# Altium schematic sheet senior_project_schem_REVB_2_26_2019_updated_without_sizes.SchDoc
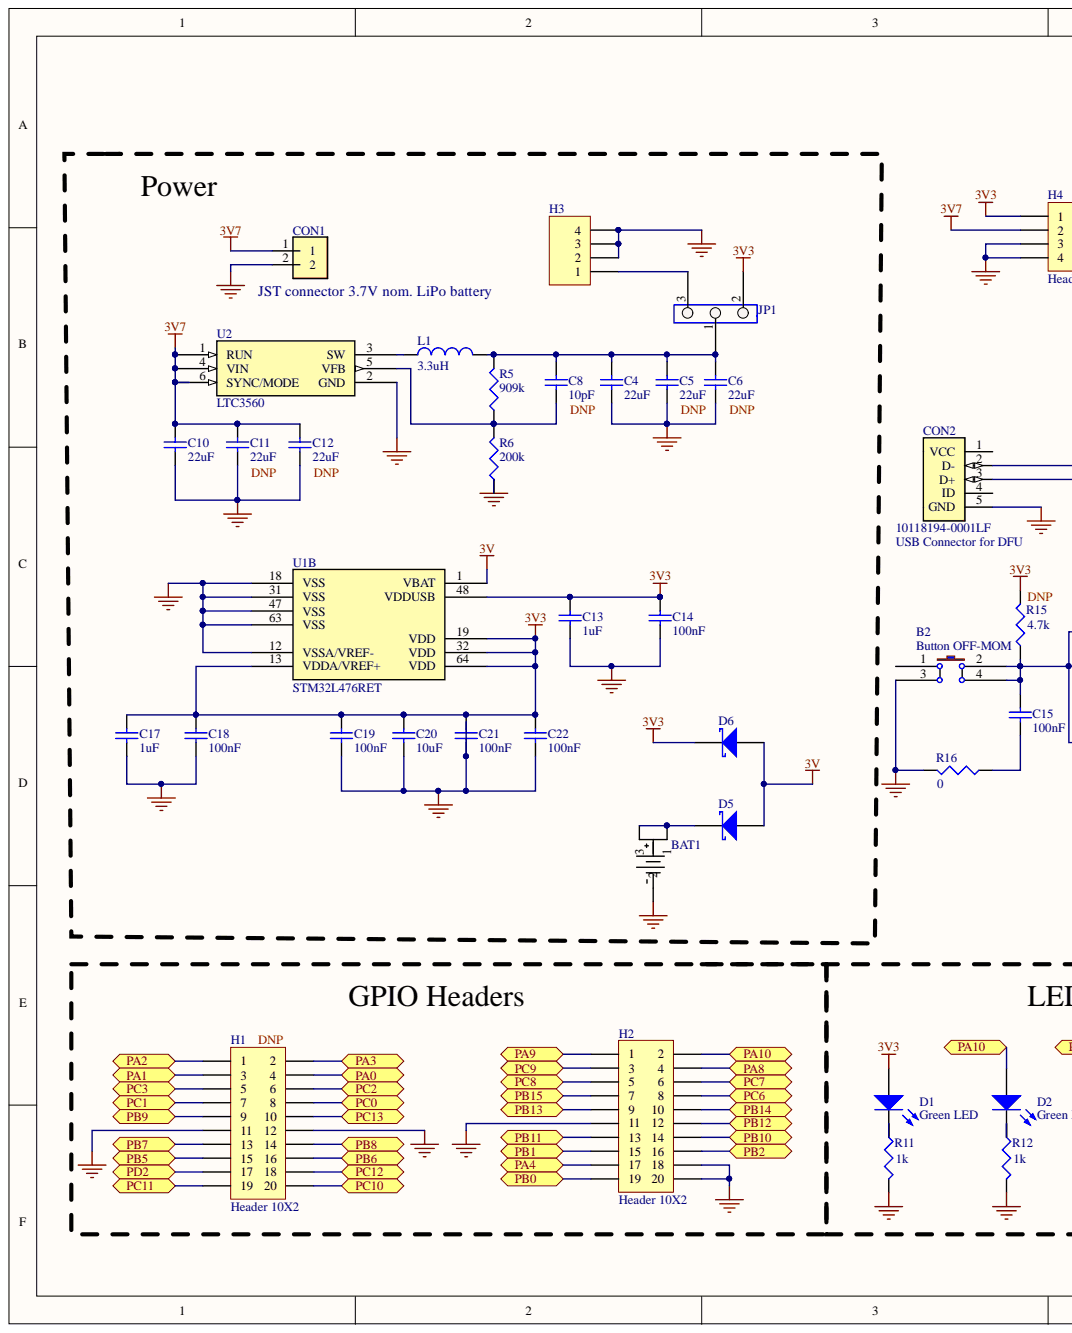
[diagram p0: senior_project_schem_REVB_2_26_2019_updated_without_sizes.SchDoc, left]
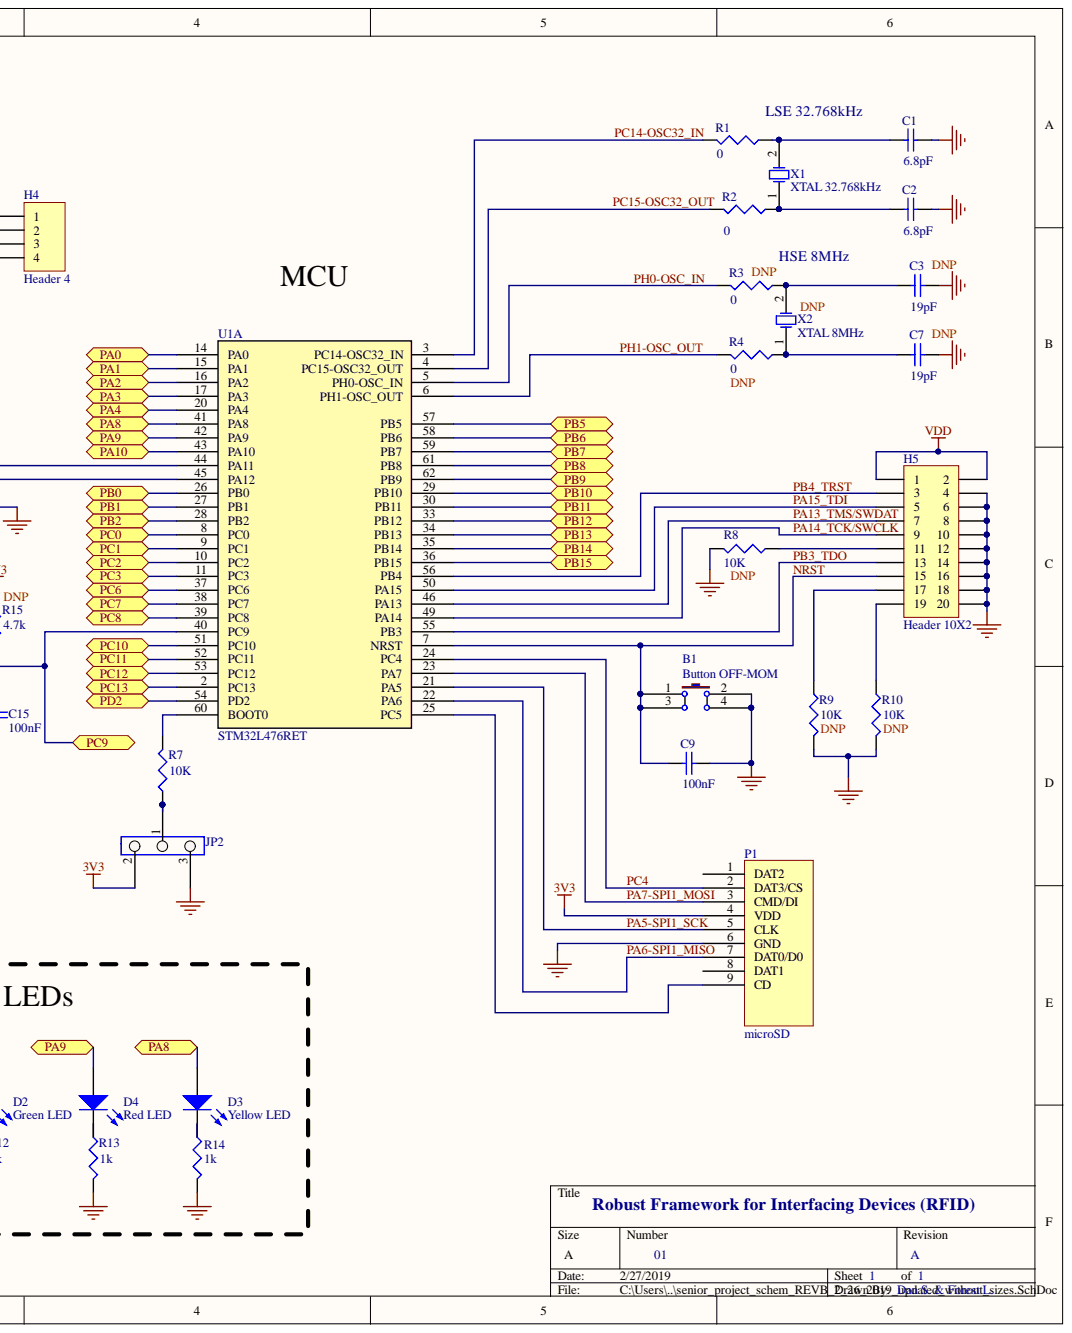
[diagram p1: senior_project_schem_REVB_2_26_2019_updated_without_sizes.SchDoc, right]

## Parts
B1  Button OFF-MOM  [9.5mmx5.6mm_SMD_Button]
B2  Button OFF-MOM  [9.5mmx5.6mm_SMD_Button]
BAT1  (no value)  [Keystone 3050 Batt Holder]
C1  value 6.8pF  [CAPC1005X55N]  (hidden comment: Cap 0402)
C2  value 6.8pF  [CAPC1005X55N]  (hidden comment: Cap 0402)
C3  value 19pF  [CAPC1005X55N]  (hidden comment: Cap 0402)
C4  22uF  [C_0805]
C5  22uF  [C_0805]
C6  22uF  [C_0805]
C7  value 19pF  [CAPC1005X55N]  (hidden comment: Cap 0402)
C8  10pF  [CAP_0603]
C9  value 100nF  [CAP_0603]  (hidden comment: Cap 0603)
C10  22uF  [C_0805]
C11  22uF  [C_0805]
C12  22uF  [C_0805]
C13  1uF  [CAP_0603]
C14  100nF  [CAP_0603]
C15  value 100nF  [CAP_0603]  (hidden comment: Cap 0603)
C17  1uF  [CAP_0603]
C18  100nF  [CAP_0603]
C19  100nF  [CAP_0603]
C20  10uF  [CAP_0603]
C21  100nF  [CAP_0603]
C22  100nF  [CAP_0603]
CON1  S2B-PH-SM4-TB(LF)(SN)  [JST_S2B-PH-SM4-TB(LF)(SN)]  (value not drawn)
CON2  10118194-0001LF  [FRAMATOME_10118194-0001LF]
D1  Green LED  [LED-0]
D2  Green LED  [LED-0]
D3  Yellow LED  [LED-0]
D4  Red LED  [LED-0]
D5  (no value)  [SMB]
D6  (no value)  [SMB]
H1  Header 10X2  [HDR2X10]
H2  Header 10X2  [HDR2X10]
H3  (no value)  [HDR1X4]
H4  Header 4  [HDR1X4]
H5  Header 10X2  [HDR2X10]
JP1  (no value)  [SIP3_HDR1X3]
JP2  (no value)  [SIP3_HDR1X3]
L1  3.3uH  [MAMK2520T3R3M]
P1  microSD  [WM12834CT-ND]
R1  value 0  [R_0603]  (hidden comment: Res 0603)
R2  value 0  [R_0603]  (hidden comment: Res 0603)
R3  value 0  [R_0603]  (hidden comment: Res 0603)
R4  value 0  [R_0603]  (hidden comment: Res 0603)
R5  909k  [R_0805]
R6  200k  [R_0805]
R7  value 10K  [R_0805]  (hidden comment: Res 0805)
R8  value 10K  [R_0805]  (hidden comment: Res 0805)
R9  value 10K  [R_0805]  (hidden comment: Res 0805)
R10  value 10K  [R_0805]  (hidden comment: Res 0805)
R11  value 1k  [R_0805]  (hidden comment: Res 0805)
R12  value 1k  [R_0805]  (hidden comment: Res 0805)
R13  value 1k  [R_0805]  (hidden comment: Res 0805)
R14  value 1k  [R_0805]  (hidden comment: Res 0805)
R15  value 4.7k  [R_0805]  (hidden comment: Res 0805)
R16  value 0  [R_0805]  (hidden comment: Res 0805)
U1  STM32L476RET  [LQFP64-10x10mm]  (parts A,B)
U2  LTC3560  [TSOT-23_S6]
X1  XTAL 32.768kHz  [ECS-.327-CDX-1128]
X2  XTAL 8MHz  [ECS-.327-CDX-1128]

## Ports
PA0, PA1, PA2, PA3, PA4, PA8, PA9, PA10, PB0, PB1, PB2, PB5, PB6, PB7, PB8, PB9, PB10, PB11, PB12, PB13, PB14, PB15, PC0, PC1, PC2, PC3, PC6, PC7, PC8, PC9, PC10, PC11, PC12, PC13, PD2

## Nets
3V: D5.1 D6.1 U1.1(VBAT)
3V3: C13.2 C14.2 C17.2 C18.2 C19.2 C20.2 C21.2 C22.2 D1.1 D6.2 H4.1 JP1.2 JP2.2 P1.4(VDD) R15.2 U1.13(VDDA/VREF+) U1.19(VDD) U1.32(VDD) U1.48(VDDUSB) U1.64(VDD)
3V7: C10.2 C11.2 C12.2 CON1.1 H4.2 U2.1(RUN) U2.4(VIN) U2.6(SYNC/MODE)
GND: B1.2 B1.4 B2.3 C1.1 C2.1 C3.2 C4.1 C5.1 C6.1 C7.2 C9.1 C10.1 C11.1 C12.1 C13.1 C14.1 C17.1 C18.1 C19.1 C20.1 C21.1 C22.1 CON1.2 CON2.5(GND) H1.11 H1.12 H2.11 H2.18 H2.20 H3.2 H3.3 H3.4 H4.3 H4.4 H5.4 H5.6 H5.8 H5.10 H5.12 H5.14 H5.16 H5.18 H5.20 JP2.3 P1.6(GND) R6.1 R8.2 R9.2 R10.2 R11.2 R12.2 R13.2 R14.2 R16.2 U1.12(VSSA/VREF-) U1.18(VSS) U1.31(VSS) U1.47(VSS) U1.63(VSS) U2.2(GND)
VDD: H5.1 H5.2
: BAT1.2
NRST: B1.1 B1.3 C9.2 H5.15 U1.7(NRST)
PA0: H1.4 U1.14(PA0) ; port PA0
PA1: H1.3 U1.15(PA1) ; port PA1
PA2: H1.1 U1.16(PA2) ; port PA2
PA3: H1.2 U1.17(PA3) ; port PA3
PA4: H2.17 U1.20(PA4) ; port PA4
PA5-SPI1_SCK: P1.5(CLK) U1.21(PA5)
PA6-SPI1_MISO: P1.7(DAT0/D0) U1.22(PA6)
PA7-SPI1_MOSI: P1.3(CMD/DI) U1.23(PA7)
PA8: D3.1 H2.4 U1.41(PA8) ; port PA8
PA9: D4.1 H2.1 U1.42(PA9) ; port PA9
PA10: D2.1 H2.2 U1.43(PA10) ; port PA10
PA13_TMS/SWDAT: H5.7 U1.46(PA13)
PA14_TCK/SWCLK: H5.9 U1.49(PA14)
PA15_TDI: H5.5 U1.50(PA15)
PB0: H2.19 U1.26(PB0) ; port PB0
PB1: H2.15 U1.27(PB1) ; port PB1
PB2: H2.16 U1.28(PB2) ; port PB2
PB3_TDO: H5.13 U1.55(PB3)
PB4_TRST: H5.3 U1.56(PB4)
PB5: H1.15 U1.57(PB5) ; port PB5
PB6: H1.16 U1.58(PB6) ; port PB6
PB7: H1.13 U1.59(PB7) ; port PB7
PB8: H1.14 U1.61(PB8) ; port PB8
PB9: H1.9 U1.62(PB9) ; port PB9
PB10: H2.14 U1.29(PB10) ; port PB10
PB11: H2.13 U1.30(PB11) ; port PB11
PB12: H2.12 U1.33(PB12) ; port PB12
PB13: H2.9 U1.34(PB13) ; port PB13
PB14: H2.10 U1.35(PB14) ; port PB14
PB15: H2.7 U1.36(PB15) ; port PB15
PC0: H1.8 U1.8(PC0) ; port PC0
PC1: H1.7 U1.9(PC1) ; port PC1
PC2: H1.6 U1.10(PC2) ; port PC2
PC3: H1.5 U1.11(PC3) ; port PC3
PC4: P1.2(DAT3/CS) U1.24(PC4)
PC6: H2.8 U1.37(PC6) ; port PC6
PC7: H2.6 U1.38(PC7) ; port PC7
PC8: H2.5 U1.39(PC8) ; port PC8
PC9: B2.2 B2.4 C15.1 H2.3 R15.1 U1.40(PC9) ; port PC9
PC10: H1.20 U1.51(PC10) ; port PC10
PC11: H1.19 U1.52(PC11) ; port PC11
PC12: H1.18 U1.53(PC12) ; port PC12
PC13: H1.10 U1.2(PC13) ; port PC13
PC14-OSC32_IN: R1.2 U1.3(PC14-OSC32_IN)
PC15-OSC32_OUT: R2.2 U1.4(PC15-OSC32_OUT)
PD2: H1.17 U1.54(PD2) ; port PD2
PH0-OSC_IN: R3.2 U1.5(PH0-OSC_IN)
PH1-OSC_OUT: R4.2 U1.6(PH1-OSC_OUT)
NetBAT1_1: BAT1.1 BAT1.3 D5.2
NetC1_2: C1.2 R1.1 X1.2
NetC2_2: C2.2 R2.1 X1.1
NetC3_1: C3.1 R3.1 X2.2
NetC4_2: C4.2 C5.2 C6.2 C8.2 JP1.1 L1.2 R5.2
NetC7_1: C7.1 R4.1 X2.1
NetC8_1: C8.1 R5.1 R6.2 U2.5(VFB)
NetC15_2: C15.2 R16.1
NetCON2_2: CON2.2(D-) U1.44(PA11)
NetCON2_3: CON2.3(D+) U1.45(PA12)
NetD1_2: D1.2 R11.1
NetD2_2: D2.2 R12.1
NetD3_2: D3.2 R14.1
NetH3_1: H3.1 JP1.3
NetH5_11: H5.11 R8.1
NetH5_17: H5.17 R9.1
NetH5_19: H5.19 R10.1
NetJP2_1: JP2.1 R7.1
NetL1_1: L1.1 U2.3(SW)
NetP1_9: P1.9(CD) U1.25(PC5)
NetR7_2: R7.2 U1.60(BOOT0)

Not connected: B2 1; CON2 1(VCC) 4(ID); D4 2; P1 1(DAT2) 8(DAT1); R13 1

## Library data of the parts
BAT1 = Keystone_3050_Bat_Hld: Imported; Manufacturer_Part_Number: BAT-HLD-001; Built_By: EMA_UL_Team; C: Copyright (C) 2015 Accelerated Designs. All rights reserved; Vendor: Linx Technologies; Component Kind: Standard; RefDes: RefDes; Type: DEV; Value: Value; Library Name: Senior_Project01.SCHLIB
C1-C3 C7 = C_0402: Capacitor; Component Kind: Standard; LatestRevisionDate: 17-Jul-2002; LatestRevisionNote: Re-released for DXP Platform.; PackageDescription: Radial Cap, Thru-Hole; 2 Leads; 0.3 in Pin Spacing; PackageReference: RAD-0.3; Published: 8-Jun-2000; Signal Integrity: Cap; Simulation: CAP; Library Name: Senior_Project01.SCHLIB; Publisher: Altium Limited
C4-C6 C10-C12 = C_0805: Capacitor; Component Kind: Standard; LatestRevisionDate: 17-Jul-2002; LatestRevisionNote: Re-released for DXP Platform.; PackageDescription: Radial Cap, Thru-Hole; 2 Leads; 0.3 in Pin Spacing; PackageReference: RAD-0.3; Published: 8-Jun-2000; Signal Integrity: Cap; Simulation: CAP; Library Name: Senior_Project01.SCHLIB; Publisher: Altium Limited
C8 C9 C13-C15 C17-C22 = C_0603: Capacitor; Component Kind: Standard; LatestRevisionDate: 17-Jul-2002; LatestRevisionNote: Re-released for DXP Platform.; PackageDescription: Radial Cap, Thru-Hole; 2 Leads; 0.3 in Pin Spacing; PackageReference: RAD-0.3; Published: 8-Jun-2000; Signal Integrity: Cap; Simulation: CAP; Library Name: Senior_Project01.SCHLIB; Publisher: Altium Limited
CON1 = S2B-PH-SM4-TB(LF)(SN): PH Series 2 Position 2 mm Pitch Surface Mount Side Entry Shrouded Header; MF: JST Sales; MP: S2B-PH-SM4-TB_LF__SN_; Component Kind: Standard; Digi-Key_Part_Number: 455-1749-1-ND; Digi-Key_Purchase_URL: https://www.digikey.com/product-detail/en/jst-sales-america-inc/S2B-PH-SM4-TB_LF__SN_/455-1749-1-ND/926846?utm_source=snapeda&utm_medium=aggregator&utm_campaign=symbol; Package: FakePackage u; SnapEDA_Link: https://www.snapeda.com/parts/S2B-PH-SM4-TB%28LF%29%28SN%29/JST%20Sales%20America%20Inc./view-part/?ref=snap; Library Name: Senior_Project01.SCHLIB
CON2 = 10118194-0001LF: Micro Usb, 2.0 Type b, Rcpt, Smt; MF: Amphenol FCI; Availability: Available; Component Kind: Standard; Library Name: 10118194-0001LF.SchLib; MP: 10118194-0001LF; Package: None; Price: None; SnapEDA_Link: https://www.snapeda.com/parts/10118194-0001LF/Amphenol%20FCI/view-part/?ref=snap
D1-D4 = LED0: Typical INFRARED GaAs LED; Component Kind: Standard; LatestRevisionDate: 08-Jul-2005; LatestRevisionNote: Mech Layer 1 removed.; Library Name: Miscellaneous Devices.IntLib; PackageDescription: LED; 2 Leads; PackageReference: LED-0; Published: 8-Jun-2000; Simulation: LED0; Publisher: Altium Limited
D5 D6 = D Schottky: Schottky Diode; Code_JEDEC: DO-214-AA; Component Kind: Standard; LatestRevisionDate: 17-Jul-2002; LatestRevisionNote: Re-released for DXP Platform.; Library Name: Miscellaneous Devices.IntLib; PackageDescription: DO-214-AA/SMB; 2 C-Bend Leads; Body 5.3 x 3.6 mm, inc. leads (LxW); PackageReference: SMB; Published: 8-Jun-2000; Signal Integrity: D Schottky; Simulation: SKYDIODE; Publisher: Altium Limited
H1 H2 H5 = Header 10X2: Header, 10-Pin, Dual row; Component Kind: Standard; LatestRevisionDate: 17-Jul-2002; LatestRevisionNote: Re-released for DXP Platform.; Library Name: Miscellaneous Connectors.IntLib; Signal Integrity: Connector; Publisher: Altium Limited
H3 H4 = Header 4: Header, 4-Pin; Component Kind: Standard; LatestRevisionDate: 17-Jul-2002; LatestRevisionNote: Re-released for DXP Platform.; Library Name: Miscellaneous Connectors.IntLib; Signal Integrity: Connector; Publisher: Altium Limited
JP1 JP2 = SIP3: Name: JP; Component Kind: Standard; Library Name: Senior_Project01.SCHLIB
L1 = Ind_1008: Inductor; Code_IEC: 1005; Code_JEITA: 0402; Component Kind: Standard; LatestRevisionDate: 29-May-2009; LatestRevisionNote: IPC-7351 Footprint Added.; PackageDescription: Chip Inductor; PackageReference: 0402-A; Published: 8-Jun-2000; Signal Integrity: Inductor; Simulation: INDUCTOR; Library Name: Senior_Project01.SCHLIB; Publisher: Altium Limited
P1 = microSD SPI 9 (8 + 1)_1: microSD card using SPI interface; Component Kind: Standard; Library Name: Connector Lib.SchLib
R1-R4 = R_0603: Resistor; Component Kind: Standard; LatestRevisionDate: 29-May-2009; LatestRevisionNote: IPC-7351 Footprint Added.; PackageDescription: Chip Resistor; PackageReference: J1-0603; Published: 8-Jun-2000; Signal Integrity: Res; Simulation: RESISTOR; Library Name: Senior_Project01.SCHLIB; Publisher: Altium Limited
R5-R16 = R_0805: Resistor; Component Kind: Standard; LatestRevisionDate: 29-May-2009; LatestRevisionNote: IPC-7351 Footprint Added.; PackageDescription: Chip Resistor; PackageReference: J1-0603; Published: 8-Jun-2000; Signal Integrity: Res; Simulation: RESISTOR; Library Name: Senior_Project01.SCHLIB; Publisher: Altium Limited
U1 = STM32L476RX: LQFP64 10x10mm; Built_By: EMA_UL_Team; Vendor: STMicroelectronics; Component Kind: Standard; Manufacturer_Part_Number: STM32L476RX; RefDes: RefDes; Type: DEV; Value: Value; Library Name: Senior_Project01.SCHLIB
U2 = LTC3560ES6#TRMPB: Imported; Manufacturer_Part_Number: LTC3560ES6#TRMPBF; Built_By: EMA_UL_Team; Vendor: Linear Technology; Component Kind: Standard; RefDes: RefDes; Type: DEV; Value: Value; Library Name: Senior_Project01.SCHLIB
X1 = XTAL_32.768kHz: Crystal Oscillator; Component Kind: Standard; LatestRevisionDate: 17-Jul-2002; LatestRevisionNote: Re-released for DXP Platform.; PackageDescription: Crystal, Thru-Hole; 2 Leads; Body 3.1 x 8.2 mm (Dia.xH); PackageReference: R38; Published: 8-Jun-2000; Simulation: XTAL; Library Name: Senior_Project01.SCHLIB; Publisher: Altium Limited
X2 = XTAL_8MHz: Crystal Oscillator 8 MHz; Component Kind: Standard; LatestRevisionDate: 17-Jul-2002; LatestRevisionNote: Re-released for DXP Platform.; PackageDescription: Crystal, Thru-Hole; 2 Leads; Body 3.1 x 8.2 mm (Dia.xH); PackageReference: R38; Published: 8-Jun-2000; Simulation: XTAL; Library Name: Senior_Project01.SCHLIB; Publisher: Altium Limited
B1 B2 = Button OFF-MOM: Component Kind: Standard; Library Name: Senior_Project01.SCHLIB
